# Altium schematic sheet STM.SchDoc(STM)
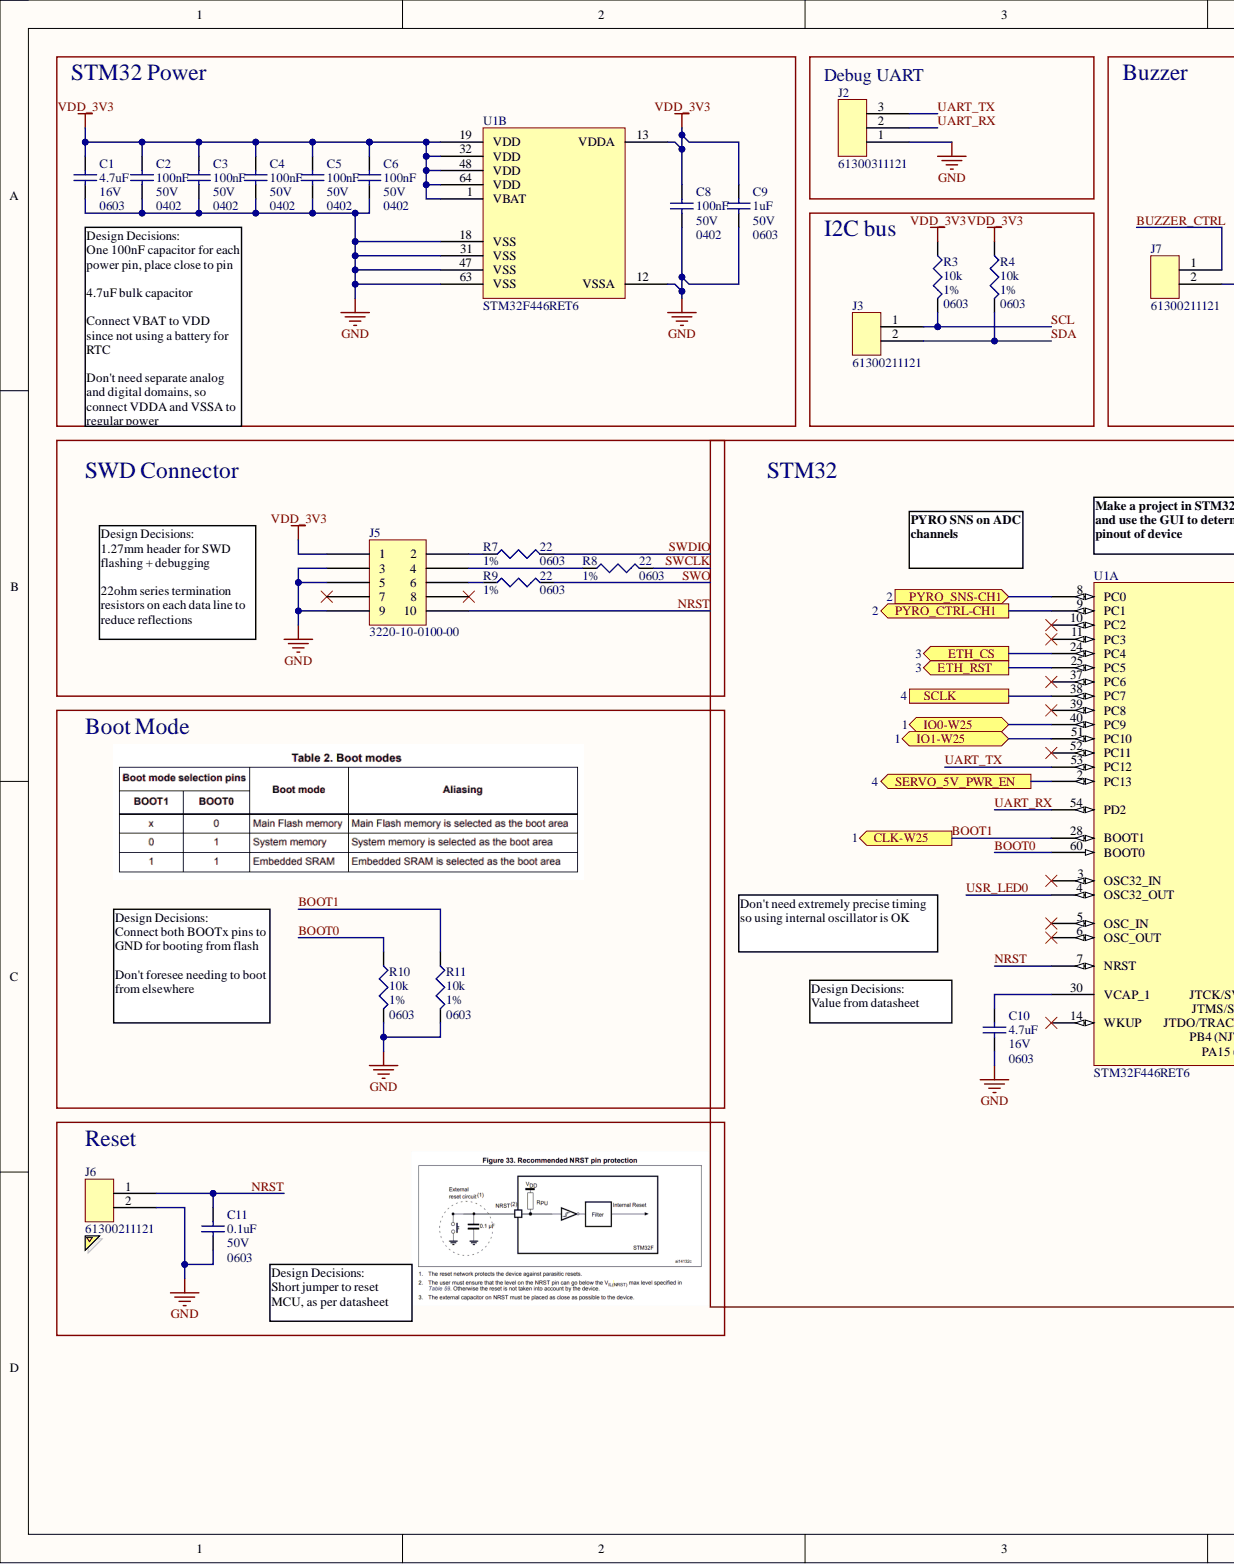
[diagram p0: STM.SchDoc, left]
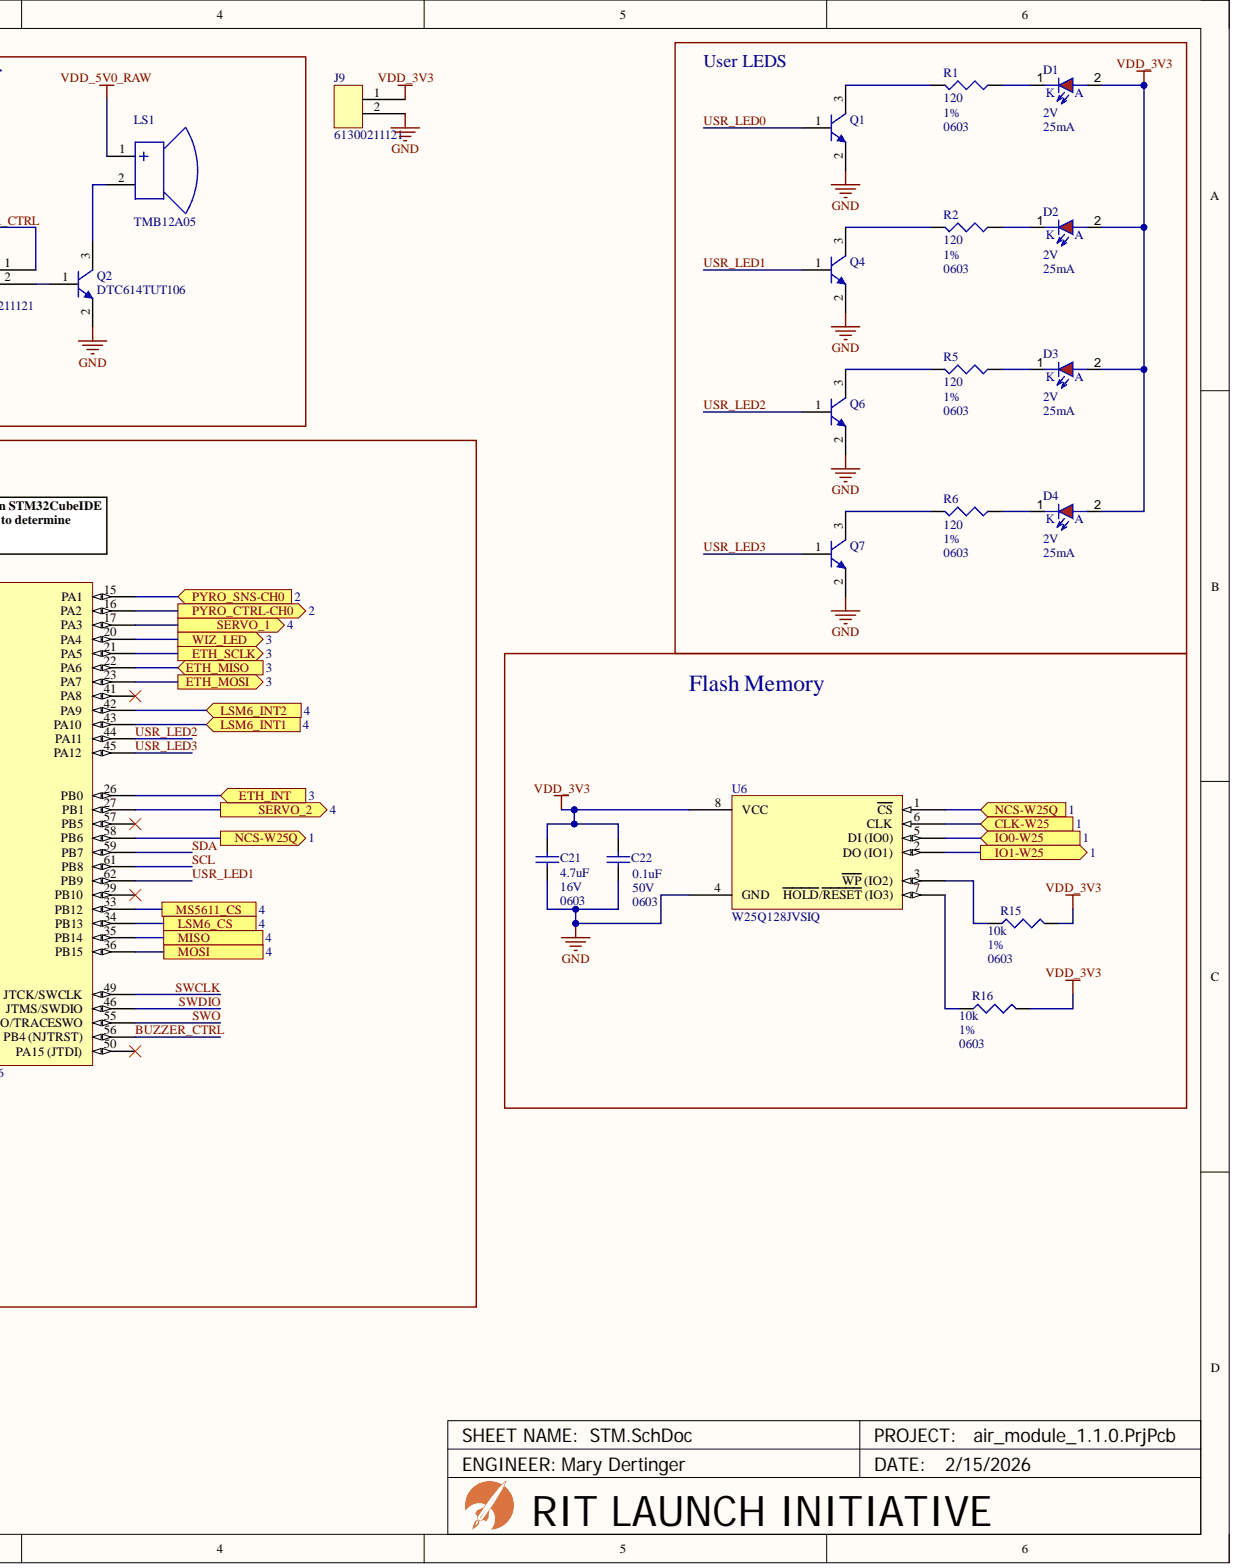
[diagram p1: STM.SchDoc, right]

## Parts
C1  Capacitance: 4.7uF  Case Code (Imperial): 0603  Voltage: 16V  (hidden comment: CL10A475KO8NNNC)
C2  Capacitance: 100nF  Case/Package: 0402  Voltage: 50V  (hidden comment: CL05B104KB54PNC)
C3  Capacitance: 100nF  Case/Package: 0402  Voltage: 50V  (hidden comment: CL05B104KB54PNC)
C4  Capacitance: 100nF  Case/Package: 0402  Voltage: 50V  (hidden comment: CL05B104KB54PNC)
C5  Capacitance: 100nF  Case/Package: 0402  Voltage: 50V  (hidden comment: CL05B104KB54PNC)
C6  Capacitance: 100nF  Case/Package: 0402  Voltage: 50V  (hidden comment: CL05B104KB54PNC)
C8  Capacitance: 100nF  Case/Package: 0402  Voltage: 50V  (hidden comment: CL05B104KB54PNC)
C9  Capacitance: 1uF  Case Code (Imperial): 0603  Voltage: 50V  (hidden comment: CL10A105KB8NNNC)
C10  Capacitance: 4.7uF  Case Code (Imperial): 0603  Voltage: 16V  (hidden comment: CL10A475KO8NNNC)
C11  Capacitance: 0.1uF  Case Code (Imperial): 0603  Voltage: 50V  (hidden comment: CC0603KRX7R9BB104)
C21  Capacitance: 4.7uF  Case Code (Imperial): 0603  Voltage: 16V  (hidden comment: CL10A475KO8NNNC)
C22  Capacitance: 0.1uF  Case Code (Imperial): 0603  Voltage: 50V  (hidden comment: CC0603KRX7R9BB104)
D1  Forward Voltage: 2V  Max Current: 25mA  (hidden comment: LED0)
D2  Forward Voltage: 2V  Max Current: 25mA  (hidden comment: LED1)
D3  Forward Voltage: 2V  Max Current: 25mA  (hidden comment: LED2)
D4  Forward Voltage: 2V  Max Current: 25mA  (hidden comment: LED3)
J2  61300311121
J3  61300211121
J5  3220-10-0100-00
J6  61300211121
J7  61300211121
J9  61300211121
LS1  TMB12A05
Q1  DTC614TUT106
Q2  DTC614TUT106
Q4  DTC614TUT106
Q6  DTC614TUT106
Q7  DTC614TUT106
R1  Case/Package: 0603  Tolerance: 1%  (hidden comment: 0603WAF1200T5E)
R2  Case/Package: 0603  Tolerance: 1%  (hidden comment: 0603WAF1200T5E)
R3  Case/Package: 0603  Resistance: 10k  Tolerance: 1%  (hidden comment: 0603WAF1002T5E)
R4  Case/Package: 0603  Resistance: 10k  Tolerance: 1%  (hidden comment: 0603WAF1002T5E)
R5  Case/Package: 0603  Tolerance: 1%  (hidden comment: 0603WAF1200T5E)
R6  Case/Package: 0603  Tolerance: 1%  (hidden comment: 0603WAF1200T5E)
R7  Case/Package: 0603  Tolerance: 1%  (hidden comment: 0603WAF220JT5E)
R8  Case/Package: 0603  Tolerance: 1%  (hidden comment: 0603WAF220JT5E)
R9  Case/Package: 0603  Tolerance: 1%  (hidden comment: 0603WAF220JT5E)
R10  Case/Package: 0603  Resistance: 10k  Tolerance: 1%  (hidden comment: 0603WAF1002T5E)
R11  Case/Package: 0603  Resistance: 10k  Tolerance: 1%  (hidden comment: 0603WAF1002T5E)
R15  Case/Package: 0603  Resistance: 10k  Tolerance: 1%  (hidden comment: 0603WAF1002T5E)
R16  Case/Package: 0603  Resistance: 10k  Tolerance: 1%  (hidden comment: 0603WAF1002T5E)
U1  STM32F446RET6  (parts A,B)
U6  W25Q128JVSIQ

## Ports
CLK-W25, ETH_CS, ETH_INT, ETH_MISO, ETH_MOSI, ETH_RST, ETH_SCLK, IO0-W25, IO1-W25, LSM6_CS, LSM6_INT1, LSM6_INT2, MISO, MOSI, MS5611_CS, NCS-W25Q, PYRO_CTRL-CH0, PYRO_CTRL-CH1, PYRO_SNS-CH0, PYRO_SNS-CH1, SCLK, SERVO_1, SERVO_2, SERVO_5V_PWR_EN, WIZ_LED

## Nets
GND: C1.1 C2.1 C3.1 C4.1 C5.1 C6.1 C8.1 C9.1 C10.1 C11.1 C21.2 C22.1 J2.1 J5.3 J5.5 J5.9 J6.2 J9.2 Q1.2 Q2.2 Q4.2 Q6.2 Q7.2 R10.2 R11.2 U1.12(VSSA) U1.18(VSS) U1.31(VSS) U1.47(VSS) U1.63(VSS) U6.4(GND)
VDD_3V3: C1.2 C2.2 C3.2 C4.2 C5.2 C6.2 C8.2 C9.2 C21.1 C22.2 D1.2 D2.2 D3.2 D4.2 J5.1 J9.1 R3.1 R4.1 R15.2 R16.2 U1.1(VBAT) U1.13(VDDA) U1.19(VDD) U1.32(VDD) U1.48(VDD) U1.64(VDD) U6.8(VCC)
VDD_5V0_RAW: LS1.1
BOOT0: R10.1 U1.60(BOOT0)
BOOT1: R11.1 U1.28(BOOT1) U6.6(CLK) ; port CLK-W25
BUZZER_CTRL: J7.1 U1.56(PB4_(NJTRST))
ETH_CS: U1.24(PC4) ; port ETH_CS
ETH_INT: U1.26(PB0) ; port ETH_INT
ETH_MISO: U1.22(PA6) ; port ETH_MISO
ETH_MOSI: U1.23(PA7) ; port ETH_MOSI
ETH_RST: U1.25(PC5) ; port ETH_RST
ETH_SCLK: U1.21(PA5) ; port ETH_SCLK
IO0-W25: U1.40(PC9) U6.5(DI_(IO0)) ; port IO0-W25
IO1-W25: U1.51(PC10) U6.2(DO_(IO1)) ; port IO1-W25
LSM6_CS: U1.34(PB13) ; port LSM6_CS
LSM6_INT1: U1.43(PA10) ; port LSM6_INT1
LSM6_INT2: U1.42(PA9) ; port LSM6_INT2
MISO: U1.35(PB14) ; port MISO
MOSI: U1.36(PB15) ; port MOSI
MS5611_CS: U1.33(PB12) ; port MS5611_CS
NCS-W25Q: U1.58(PB6) U6.1(CS) ; port NCS-W25Q
NRST: C11.2 J5.10 J6.1 U1.7(NRST)
PYRO_CTRL-CH0: U1.16(PA2) ; port PYRO_CTRL-CH0
PYRO_CTRL-CH1: U1.9(PC1) ; port PYRO_CTRL-CH1
PYRO_SNS-CH0: U1.15(PA1) ; port PYRO_SNS-CH0
PYRO_SNS-CH1: U1.8(PC0) ; port PYRO_SNS-CH1
SCL: J3.1 R3.2 U1.61(PB8)
SCLK: U1.38(PC7) ; port SCLK
SDA: J3.2 R4.2 U1.59(PB7)
SERVO_1: U1.17(PA3) ; port SERVO_1
SERVO_2: U1.27(PB1) ; port SERVO_2
SERVO_5V_PWR_EN: U1.2(PC13) ; port SERVO_5V_PWR_EN
SWCLK: R8.2 U1.49(JTCK/SWCLK)
SWDIO: R7.2 U1.46(JTMS/SWDIO)
SWO: R9.2 U1.55(JTDO/TRACESWO)
UART_RX: J2.2 U1.54(PD2)
UART_TX: J2.3 U1.53(PC12)
USR_LED0: Q1.1 U1.4(OSC32_OUT)
USR_LED1: Q4.1 U1.62(PB9)
USR_LED2: Q6.1 U1.44(PA11)
USR_LED3: Q7.1 U1.45(PA12)
WIZ_LED: U1.20(PA4) ; port WIZ_LED
NetC10_2: C10.2 U1.30(VCAP_1)
NetD1_1: D1.1 R1.1
NetD2_1: D2.1 R2.1
NetD3_1: D3.1 R5.1
NetD4_1: D4.1 R6.1
NetJ5_2: J5.2 R7.1
NetJ5_4: J5.4 R8.1
NetJ5_6: J5.6 R9.1
NetJ7_2: J7.2 Q2.1
NetLS1_2: LS1.2 Q2.3
NetQ1_3: Q1.3 R1.2
NetQ4_3: Q4.3 R2.2
NetQ6_3: Q6.3 R5.2
NetQ7_3: Q7.3 R6.2
NetR15_1: R15.1 U6.3(WP_(IO2))
NetR16_1: R16.1 U6.7(HOLD/RESET_(IO3))

Not connected: J5 7 8; U1 3(OSC32_IN) 5(OSC_IN) 6(OSC_OUT) 10(PC2) 11(PC3) 14(WKUP) 29(PB10) 37(PC6) 39(PC8) 41(PA8) 50(PA15_(JTDI)) 52(PC11) 57(PB5)

## Library data of the parts
C1 C10 C21 = CMP-001-0241-2: CAP CER 4.7UF 16V X5R 0603; Material: Ceramic; Tolerance: 10%; Case Code (Metric): 1608; Case/Package: 0603; Component Kind: Standard; Construction: Flat; Contact Plating: Tin; Depth: 0.8mm; Dielectric: X5R; Height: 0.8mm; JLCPCB Part #: C19666; Length: 1.6mm; Max Operating Temperature: 85°C; Min Operating Temperature: -55°C; Mount: Surface Mount; Package Quantity: 1; Packaging: Tape and Reel; Radiation Hardening: No; RoHS Compliant: Yes; Series: CL; Thickness: 0.035inch; Voltage Rating: 16V; Width: 0.031inch; Library Name: RIT Launch Initiative
C2-C6 C8 = CMP-001-0348-1: CAP CER 0.1UF 50V X7R 0402; Component Kind: Standard; JLCPCB Part #: C307331; RoHS Compliant: Yes; Library Name: RIT Launch Initiative
C9 = CMP-001-0307-1: CAP CER 1UF 50V X5R 0603; Tolerance: 10%; Case Code (Metric): 1608; Case/Package: 0603; Component Kind: Standard; Construction: Flat; Contact Plating: Tin; Depth: 0.8mm; Dielectric: X5R; JLCPCB Part #: C15849; Length: 1.6mm; Max Operating Temperature: 85°C; Min Operating Temperature: -55°C; Mounting Technology: Surface Mount; Package Quantity: 1; Packaging: Tape and Reel; Radiation Hardening: No; RoHS Compliant: Yes; Series: CL; Termination: Wraparound; Thickness: 0.035inch; Width: 0.031inch; Library Name: RIT Launch Initiative
C11 C22 = CMP-001-0242-3: CAP CER 0.1UF 50V X7R 0603; Dielectric Material: Ceramic; Tolerance: 10%; Case Code (Metric): 1608; Case/Package: 0603; Component Kind: Standard; Depth: 0.8mm; Dielectric: X7R; Halogen Free: Halogen Free; Height: 0.8mm; JLCPCB Part #: C14663; Lead Free: Lead Free; Length: 0.063inch; Max Operating Temperature: 125°C; Min Operating Temperature: -55°C; Mount: Surface Mount; Number of Pins: 2; Package Quantity: 1; Packaging: Tape and Reel; Radiation Hardening: No; REACH SVHC: No SVHC; RoHS Compliant: Yes; Series: CC; Termination: SMD/SMT; Thickness: 0.035inch; Voltage Rating: 50V; Width: 0.031inch; Library Name: RIT Launch Initiative
D1-D4 = CMP-001-0246-3: LED RED CLEAR 0805 SMD; Component Kind: Standard; JLCPCB Part #: C84256; Library Name: RIT Launch Initiative
J2 = CMP-001-0297-1: CONN HEADER 3 POS 2.54; Connector Type: Male Pin; Gender: Male; Number of Contacts: 3; Pitch: 0.1inch; Color: Black; Component Kind: Standard; Contact Material: Copper; Contact Mating Length: 0.236inch; Contact Plating: Gold; Contact Type: Male Pin; JLCPCB Part #: C429954; Lead Free: Lead Free; Mounting Technology: Through Hole; Number of Positions: 3; Number of Rows: 1; Packaging: Bulk; RoHS Compliant: Yes; Series: WR-PHD; Termination: Solder; Library Name: RIT Launch Initiative
J3 J6 J7 J9 = CMP-001-0080-1: CONN HEADER VERT 2POS 2.54MM; Component Kind: Standard; Library Name: RIT Launch Initiative
J5 = CMP-001-0340-1: CONN HEADER VERT 10POS 1.27MM; Number of Positions: 10; Pitch: 0.05inch; Component Kind: Standard; Connector Type: Header; Contact Finish - Mating: GOLD; Contact Material: Brass; Contact Plating: Gold; Contact Shape: Square; Contact Type: Male Pin; Fastening Type: Pull; Flammability Rating: UL94 V-0; Insulation Material: Nylon; Max Operating Temperature: 105°C; Min Operating Temperature: -40°C; Mounting Technology: Through Hole; Number of Rows: 2; Package Quantity: 3200; Packaging: Tray; RoHS Compliant: Yes; Row Spacing: 0.05inch; Series: -; Termination: Solder; Library Name: RIT Launch Initiative
LS1 = CMP-001-0337-1: BUZZER MAGNETIC 5V 12MM TH; Component Kind: Standard; JLCPCB Part #: C781860; Library Name: RIT Launch Initiative
Q1 Q2 Q4 Q6 Q7 = CMP-009-00209-5: No Description Available; Mfr_Name: ROHM Semiconductor; Case/Package: SOT-323; Component Kind: Standard; Design Item ID: DTC614TUT106; JLCPCB Part #: C79963; Max Operating Temperature: 150°C; Pins: 3; RoHS Compliant: Yes; Source: DTC614TUT106.SchLib; Library Name: RIT Launch Initiative
R1 R2 R5 R6 = CMP-001-0272-1: RES 120 OHM 1% 1/10W 0603; Resistance: 120; Component Kind: Standard; JLCPCB Part #: C22787; Library Name: RIT Launch Initiative
R3 R4 R10 R11 R15 R16 = CMP-001-0268-1: RES 10K OHM 1% 1/10W 0603; Component Kind: Standard; JLCPCB Part #: C25804; RoHS Compliant: Yes; Library Name: RIT Launch Initiative
R7-R9 = CMP-001-0306-1: RES 22 OHM 1% 1/10W 0603; Resistance: 22; Component Kind: Standard; JLCPCB Part #: C23345; RoHS Compliant: Yes; Library Name: RIT Launch Initiative
U1 = CMP-001-0264-3: IC MCU 32BIT 512KB FLASH 64LQFP; Core Architecture: ARM; Data Bus Width: 32b; Frequency: 180MHz; Interface: I2C,SPI,USB,CAN,IrDA,SD,UART,USART,LIN,EBI/EMI; Max Power Dissipation: 345mW; Max Supply Voltage: 3.6V; Min Supply Voltage: 1.7V; Number of I/Os: 50; Number of Timers/Counters: 14; Oscillator Type: Internal; Peripherals: Brown-out Detect/Reset,POR,PWM,WDT,DMA,LVD; RAM Size: 128KB; Watchdog Timer: Yes; Case/Package: LQFP; Component Kind: Standard; JLCPCB Part #: C69336; Lead Free: Lead Free; Max Frequency: 180MHz; Max Operating Temperature: 85°C; Memory Size: 512KB; Memory Type: FLASH; Min Operating Temperature: -40°C; Mount: Surface Mount; Number of Pins: 64; Number of Programmable I/O: 50; Packaging: Tray; REACH SVHC: No SVHC; RoHS Compliant: Yes; Series: STM32 F4; Library Name: RIT Launch Initiative
U6 = CMP-019-00058-2: NOR Flash Serial (SPI, Dual SPI, Quad SPI), 3.3V, 128M-bit, 8-Pin SOIC; Interface: SPI,Serial; Memory Type: Non-Volatile NOR FLASH; Operating Voltage: 2.7 to 3.6V; Case/Package: 8-Pin SOIC; Component Kind: Standard; JLCPCB Part #: C97521; Memory Size: 128M-bit; Operating Temperature Range: -40°C to 85°C; RoHS Compliant: Yes; Series: SpiFlash®; Library Name: RIT Launch Initiative
